# Altium schematic sheet WiFi控制模块.SchDoc
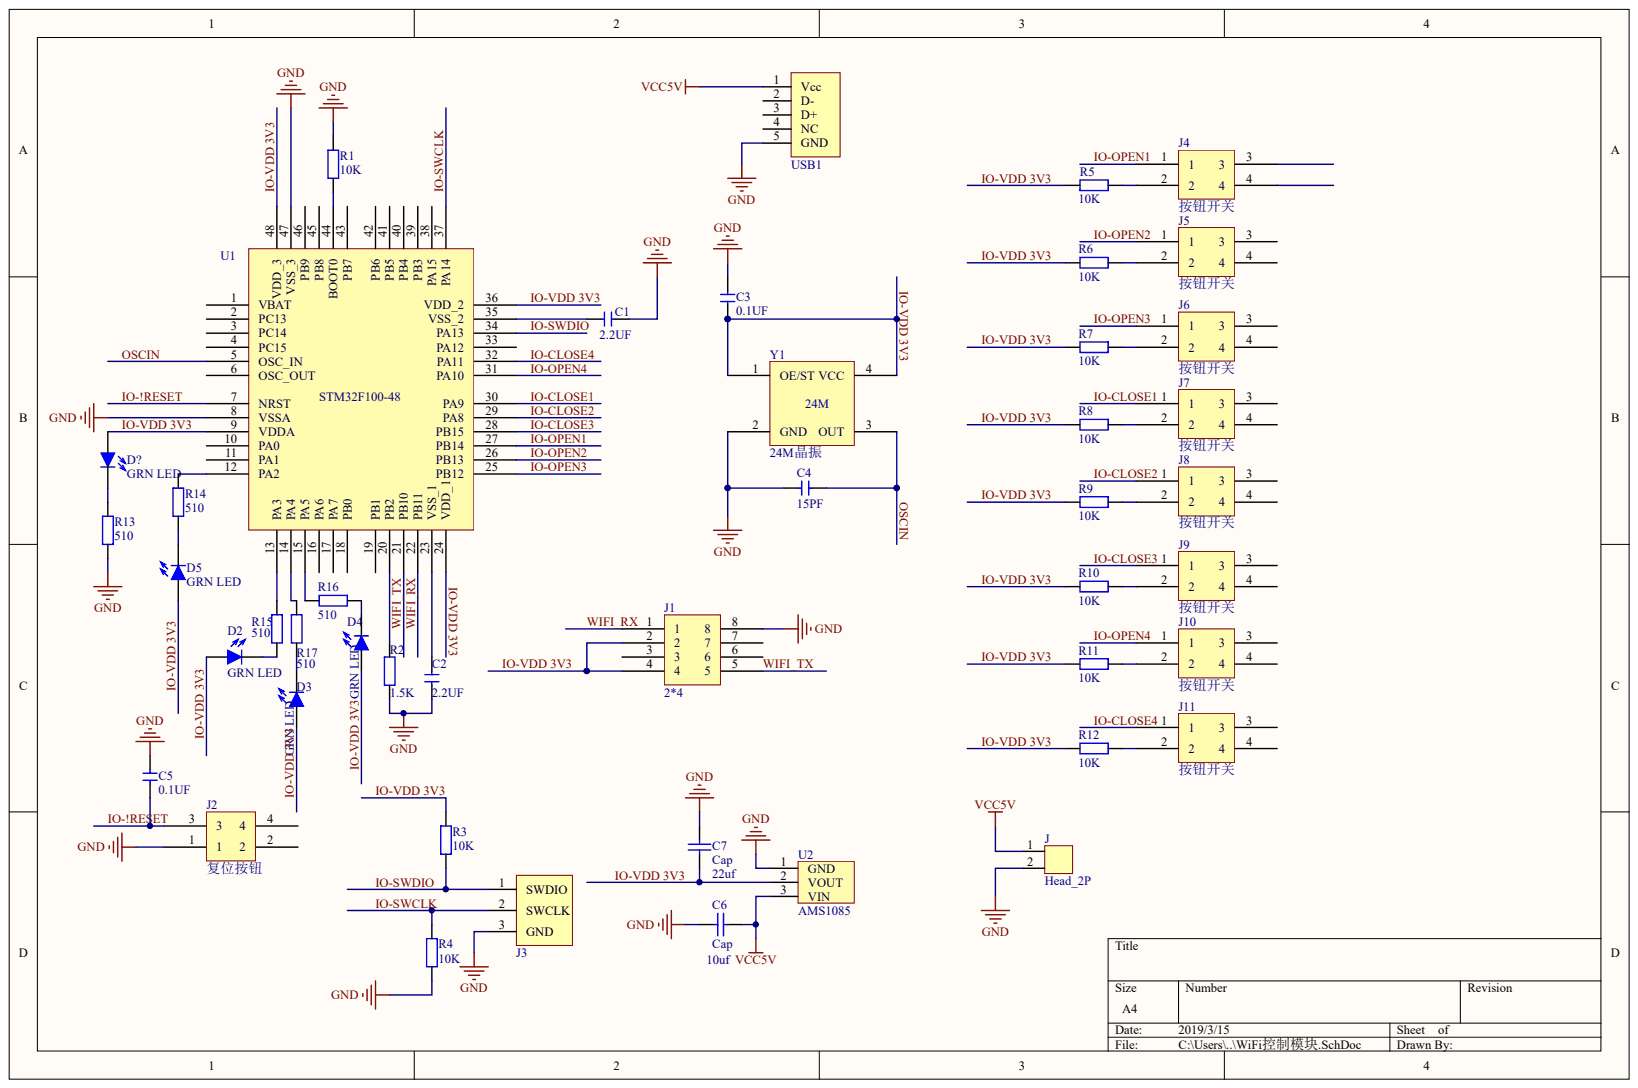
[diagram p0: WiFi控制模块.SchDoc]

## Parts
C1  2.2UF
C2  2.2UF
C3  0.1UF
C4  15PF
C5  0.1UF
C6  Cap  value 10uf
C7  Cap  value 22uf
D2  GRN LED
D3  GRN LED
D4  GRN LED
D5  GRN LED
D?  GRN LED
J  Head_2P
J1  2*4
J2  复位按钮
J3  烧写触点  (value not drawn)
J4  按钮开关
J5  按钮开关
J6  按钮开关
J7  按钮开关
J8  按钮开关
J9  按钮开关
J10  按钮开关
J11  按钮开关
R1  10K
R2  1.5K
R3  10K
R4  10K
R5  10K
R6  10K
R7  10K
R8  10K
R9  10K
R10  10K
R11  10K
R12  10K
R13  510
R14  510
R15  510
R16  510
R17  510
U1  STM32F100-48
U2  AMS1085
USB1  MOLEX-47346-0001  (value not drawn)
Y1  24M晶振

## Nets
GND: C1.2 C2.1 C3.2 C4.1 C5.2 C6.1 C7.2 J.2 J1.8 J2.1 J3.3 R1.2 R2.1 R4.1 R13.1 U1.8(VSSA) U1.47(VSS_3) U2.1 USB1.5(GND) Y1.2(GND)
VCC5V: C6.2 J.1 U2.3 USB1.1(Vcc)
IO-!RESET: C5.1 J2.3 U1.7(NRST)
IO-CLOSE1: J7.1 U1.30(PA9)
IO-CLOSE2: J8.1 U1.29(PA8)
IO-CLOSE3: J9.1 U1.28(PB15)
IO-CLOSE4: J11.1 U1.32(PA11)
IO-OPEN1: J4.1 U1.27(PB14)
IO-OPEN2: J5.1 U1.26(PB13)
IO-OPEN3: J6.1 U1.25(PB12)
IO-OPEN4: J10.1 U1.31(PA10)
IO-SWCLK: J3.2 R4.2 U1.37(PA14)
IO-SWDIO: J3.1 R3.1 U1.34(PA13)
IO-VDD 3V3: C3.1 C7.1 D2.1 D3.1 D4.1 D5.1 D?.1 J1.2 J1.4 R3.2 R5.2 R6.1 R7.1 R8.1 R9.1 R10.1 R11.1 R12.1 U1.9(VDDA) U1.24(VDD_1) U1.36(VDD_2) U1.48(VDD_3) U2.2 Y1.1(OE/ST) Y1.4(VCC)
OSCIN: C4.2 U1.5(OSC_IN) Y1.3(OUT)
WIFI_RX: J1.1 U1.22(PB11)
WIFI_TX: J1.5 U1.21(PB10)
NetC1_1: C1.1 U1.35(VSS_2)
NetC2_2: C2.2 U1.23(VSS_1)
NetD2_2: D2.2 R15.1
NetD3_2: D3.2 R17.1
NetD4_2: D4.2 R16.2
NetD5_2: D5.2 R14.1
NetD?_2: D?.2 R13.2
NetJ4_2: J4.2 R5.1
NetJ5_2: J5.2 R6.2
NetJ6_2: J6.2 R7.2
NetJ7_2: J7.2 R8.2
NetJ8_2: J8.2 R9.2
NetJ9_2: J9.2 R10.2
NetJ10_2: J10.2 R11.2
NetJ11_2: J11.2 R12.2
NetR1_1: R1.1 U1.44(BOOT0)
NetR2_2: R2.2 U1.20(PB2)
NetR14_2: R14.2 U1.12(PA2)
NetR15_2: R15.2 U1.13(PA3)
NetR16_1: R16.1 U1.15(PA5)
NetR17_2: R17.2 U1.14(PA4)

Not connected: J1 3 6 7; J2 2 4; J4 3 4; J5 3 4; J6 3 4; J7 3 4; J8 3 4; J9 3 4; J10 3 4; J11 3 4; U1 1(VBAT) 2(PC13) 3(PC14) 4(PC15) 6(OSC_OUT) 10(PA0) 11(PA1) 16(PA6) 17(PA7) 18(PB0) 19(PB1) 33(PA12) 38(PA15) 39(PB3) 40(PB4) 41(PB5) 42(PB6) 43(PB7) 45(PB8) 46(PB9); USB1 2(D-) 3(D+) 4(NC)

## Library data of the parts
C1-C7 D2-D5 D? J J1-J11 R1-R17 U1 U2 USB1 Y1 = (no symbol name)
  C6 C7: Published: 8-Jun-2000; LatestRevisionDate: 17-Jul-2002; LatestRevisionNote: Re-released for DXP Platform.; PackageReference: RAD-0.3; PackageDescription: Radial Cap, Thru-Hole; 2 Leads; 0.3 in Pin Spacing; Publisher: Altium Limited
